# Altium schematic sheet main_master.SchDoc(main_master)
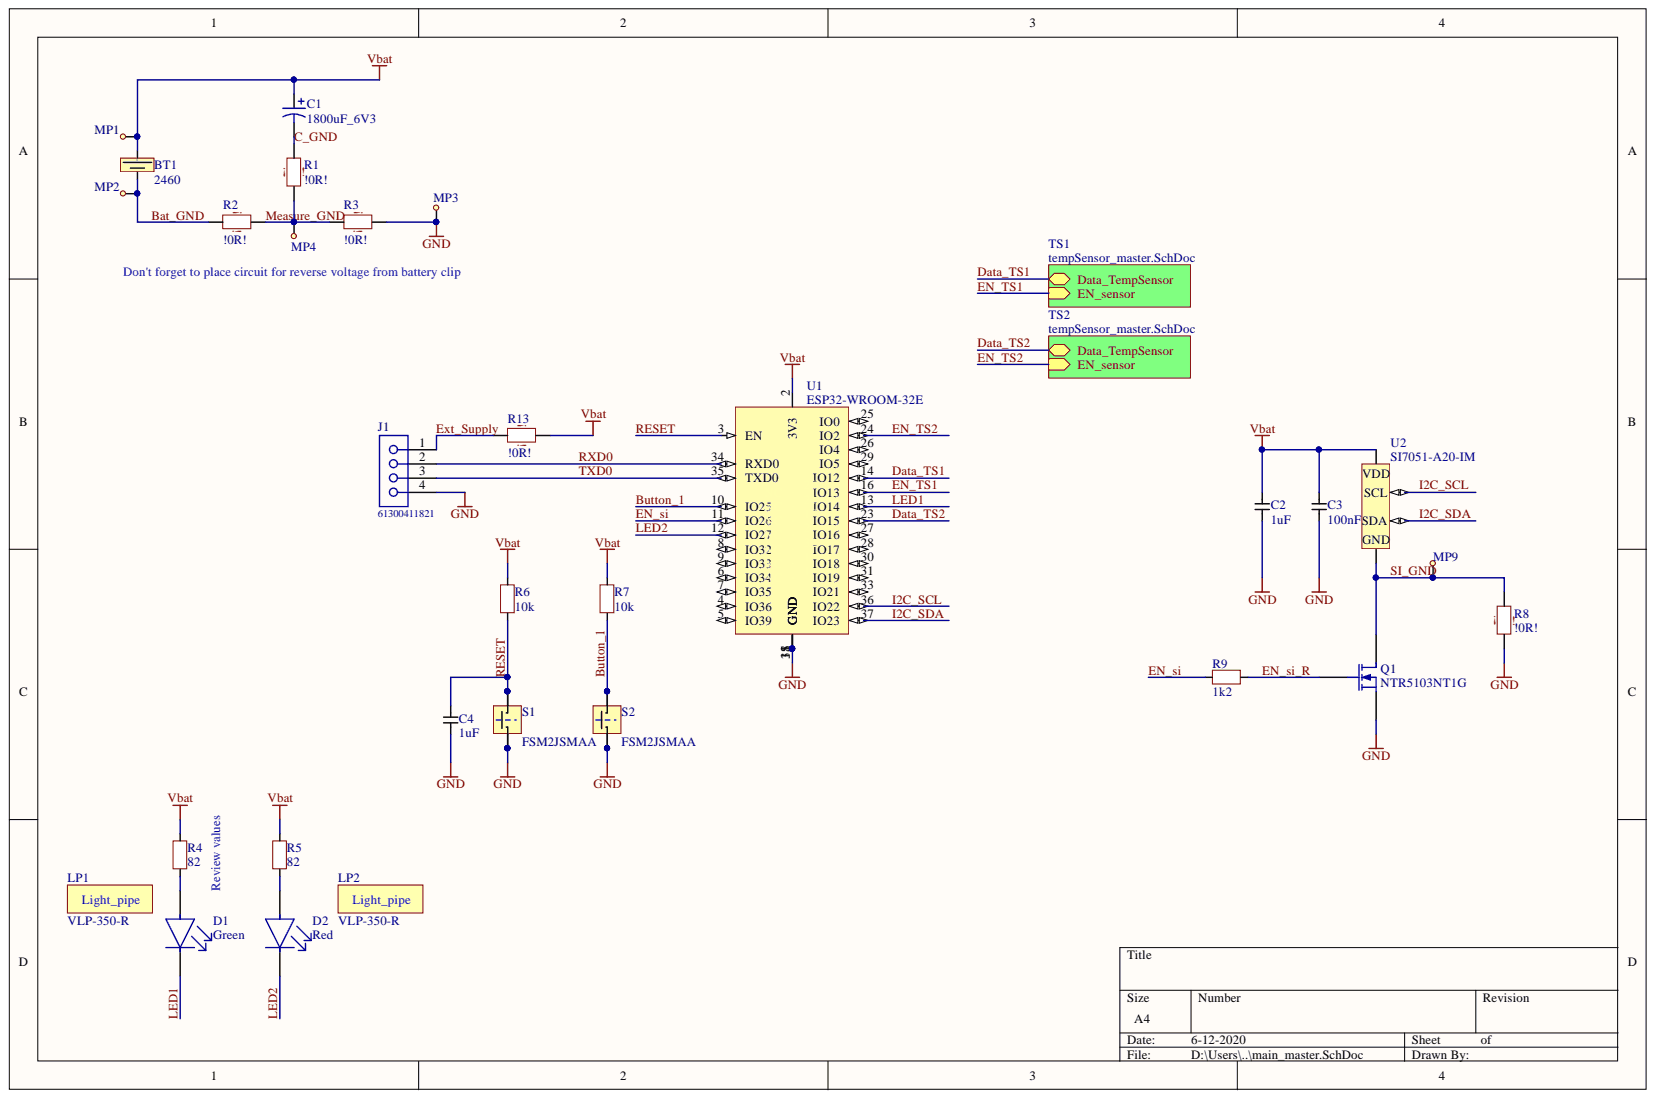
[diagram p0: main_master.SchDoc]

## Parts
BT1  2460  [KEYSTONE_2410]
C1  1800uF_6V3  [CAPAE109103X105M]
C2  1uF  [C1206]
C3  100nF  [C1206]
C4  1uF  [C1206]
D1  Green  [Kingbright_KPT-2012EC_0]
D2  Red  [Kingbright_KPT-2012EC_0]
J1  61300411821  [61300411821]
LP1  VLP-350-R  [VLP-350-R]
LP2  VLP-350-R  [VLP-350-R]
MP1  Measure_point  [MeasurePoint_1.5mm]  (value not drawn)  (no BOM)
MP2  Measure_point  [MeasurePoint_1.5mm]  (value not drawn)  (no BOM)
MP3  Measure_point  [MeasurePoint_1.5mm]  (value not drawn)  (no BOM)
MP4  Measure_point  [MeasurePoint_1.5mm]  (value not drawn)  (no BOM)
MP9  Measure_point  [MeasurePoint_1.5mm]  (value not drawn)  (no BOM)
Q1  NTR5103NT1G  [SOT23]
R1  !0R!  [R1206_Simple-Assemble]  (no BOM)
R2  !0R!  [R1206_Simple-Assemble]  (no BOM)
R3  !0R!  [R1206_Simple-Assemble]  (no BOM)
R4  82  [R1206_Simple-Assemble]
R5  82  [R1206_Simple-Assemble]
R6  10k  [R1206_Simple-Assemble]
R7  10k  [R1206_Simple-Assemble]
R8  !0R!  [R1206_Simple-Assemble]  (no BOM)
R9  1k2  [R1206_Simple-Assemble]
R13  !0R!  [R1206_Simple-Assemble]  (no BOM)
S1  FSM2JSMAA  [FP-FSM2JSMAA-MFG]
S2  FSM2JSMAA  [FP-FSM2JSMAA-MFG]
U1  ESP32-WROOM-32E  [ESP32­WROOM­32E]
U2  SI7051-A20-IM  [3x3 6-pin DFN]

## Nets
GND: C2.1 C3.1 C4.1 J1.4 MP3.1 Q1.2 R3.2 R8.1 S1.1 S1.3 S2.1 S2.3 U1.1(GND) U1.15 U1.38
Vbat: BT1.1 C1.1 C2.2 C3.2 MP1.1 R4.2 R5.2 R6.2 R7.2 R13.2 U1.2(3V3) U2.5
Bat_GND: BT1.2 MP2.1 R2.1
Button_1: R7.1 S2.2 S2.4 U1.10(IO25)
C_GND: C1.2 R1.2
Data_TS1: U1.14(IO12)
Data_TS2: U1.23(IO15)
EN_si: R9.1 U1.11(IO26)
EN_si_R: Q1.1 R9.2
EN_TS1: U1.16(IO13)
EN_TS2: U1.24(IO2)
Ext_Supply: J1.1 R13.1
I2C_SCL: U1.36(IO22) U2.6(SCL)
I2C_SDA: U1.37(IO23) U2.1(SDA)
LED1: D1.1 U1.13(IO14)
LED2: D2.1 U1.12(IO27)
Measure_GND: MP4.1 R1.1 R2.2 R3.1
RESET: C4.2 R6.1 S1.2 S1.4 U1.3(EN)
RXD0: J1.2 U1.34(RXD0)
SI_GND: MP9.1 Q1.3 R8.2 U2.2
TXD0: J1.3 U1.35(TXD0)
NetD1_2: D1.2 R4.1
NetD2_2: D2.2 R5.1
NetU1_4: U1.4(IO36)
NetU1_5: U1.5(IO39)
NetU1_6: U1.6(IO34)
NetU1_7: U1.7(IO35)
NetU1_8: U1.8(IO32)
NetU1_9: U1.9(IO33)
NetU1_17: U1.17
NetU1_18: U1.18
NetU1_19: U1.19
NetU1_20: U1.20
NetU1_21: U1.21
NetU1_22: U1.22
NetU1_25: U1.25(IO0)
NetU1_26: U1.26(IO4)
NetU1_27: U1.27(IO16)
NetU1_28: U1.28(IO17)
NetU1_29: U1.29(IO5)
NetU1_30: U1.30(IO18)
NetU1_31: U1.31(IO19)
NetU1_32: U1.32
NetU1_33: U1.33(IO21)

## Library data of the parts
BT1 = 2460: Battery Holder, AA x 1, Through Hole; Brand: KEYSTONE; Type: 2460; Mouser_Price: 1,10; Mouser_Order_Code: 534-2460; Library Name: Misc.IntLib; Farnell_Price: 1,80; Farnell_Order_Code: 1702629; Component Kind: Standard
C1 = 1800uF_6V3: SMD Aluminium Electrolytic Capacitor, Radial Can, 1800 µF, 10 V, 0.092 ohm, TRV Series; Manufacturer Part Number: EEE-FN0J182UV; Manufacturer: Panasonic; Voltage Rating: 10V; Value: 1800uF; Capacitance: 1.8mF; Supplier Unit Price 1: €0.98708; Supplier Part Number 1: 667-EEE-FN0J182UV; Supplier 1: Mouser; Mount: Surface Mount; Library Name: Capacitors_electrolytic.SchLib; Component Kind: Standard; Category: Aluminum Electrolytic Capacitors
C2 C4 = 1uF,1206: 1uF, 1206; Library Name: Capacitors.IntLib; Component Kind: Standard; Capacitance: 1uF
C3 = 100nF,1206: 100nF, 1206; Library Name: Capacitors.IntLib; Component Kind: Standard; Capacitance: 100nF
D1 = AP2012MGC: Standard LEDs - SMD GRN WATER CLEAR 0805; Manufacturer: Kingbright; Forward Voltage: 2.1V; Forward Current: 20mA; Supplier Part Number 1: 604-AP2012MGC; Supplier 1: Mouser; Manufacturer Part Number: AP2012MGC; Component Kind: Standard; Color: Green; Category: LEDs; Case/Package: 0805; Library Name: LED_AND_DISPLAYS.IntLib
D2 = KPT-2012EC: KPT-2012EC -  LED, Low Power, Red, SMD, 0805 [2012 Metric], 30 mA, 2 V, 617 nm; Order Code: 2099236; Brand: KINGBRIGHT; Type: KPT-2012EC; Supplier: Farnell; Price: 0,112; Component Kind: Standard; Library Name: LED_AND_DISPLAYS.IntLib
J1 = 61300411821: THT Vertical Socket Header WR-PHD, Pitch 2.54 mm, Single Row, 4 pins; Working Voltage: 250 VAC; Withstanding Voltage: 500 VAC; Rated Current: 3 A; Pitch: 2.54 mm; Pin Number: 4; Gender: Female; Contact Resistance: 20 mOhm; Row Number: Single; Published Date: August 2018; Part Number: 61300411821; Packaging: Tray; Orientation: Vertical; Operating Temperature: -40 to 125 degC; Mounting Technology: THT; ComponentLink2URL: https://katalog.we-online.de/em/datasheet/6130xx11821.pdf; ComponentLink2Description: Datasheet; ComponentLink1URL: http://www.we-online.de; ComponentLink1Description: Manufacturer; Component Kind: Standard; Publisher: Wurth Elektronik; Library Name: Connectoren.IntLib
LP1 LP2 = VLP-350-R: LIGHT PIPE, SINGLE, ROUND, PCB; Manufacturer: BIVAR; Manufacturer Part Number: VLP-350-R; Component Kind: Standard; Library Name: Light_Pipes.IntLib
MP1-MP4 MP9 = Measure_point: Measurepoint; Library Name: Misc.IntLib
Q1 = NTR5103NT1G: Power MOSFET, N Channel, 60 V, 260 mA, 1 ohm, SOT-23, Surface Mount; Manufacturer: ON Semiconductor; Manufacturer Part Number: NTR5103NT1G; Library Name: MOSFETS.IntLib; Component Kind: Standard
R1-R3 R8 R13 = 0R, optional resistor: normally shorted, but easy to change to an resistor footprint
  R1-R3 R8: Library Name: Resistors.SchLib
  R13: Library Name: Resistors.IntLib
R4 R5 = 82, 1206: Brand: MULTICOMP; Supplier: Farnell; Resistance: 82; Library Name: Resistors.IntLib; Component Kind: Standard
R6 R7 = 10k, 1206: 10k, 1206, 250mW, 200V; Order Code: 2861956; Brand: TE CONNECTIVITY; Type: CRGCQ1206J10K; Supplier: Farnell; Resistance: 10k; Price: 0,0139; Power: 250mW; Library Name: Resistors.IntLib; Component Kind: Standard
R9 = 1k2, 1206: SMD Chip Resistor, 1206 [3216 Metric], 1 kohm, MCSR 12 Series, 200 V, Ceramic, 250 mW; Power: 250mW; Order Code: 2446791; Brand: MULTICOMP; Type: MCWR12X1201FTL; Supplier: Farnell; Resistance: 1k2; Price: 0,0298; Library Name: Resistors.IntLib; Component Kind: Standard
S1 S2 = FSM2JSMAA: Tactile Switch, FSMJSM Series, Top Actuated, Surface Mount, Round Button, 260 gf, 50mA at 24VDC; Manufacturer: TE Connectivity; Voltage Rating (DC): 24V; Connector Type: SMD/SMT; Supplier Unit Price 1: €0.13022; Mount: Surface Mount; Manufacturer Part Number: FSM2JSMAA; Library Name: Misc.SchLib; Component Kind: Standard; Category: Pushbutton Switches; Case/Package: SMD/SMT
U1 = ESP32-WROOM-32E(M113EH2800PH3Q0): USB-modules (802.11) SMD Module ESP32-WROOM-32E, ESP32-D0WD-V3, 16 MB SPI flash, PCB antenna; Order Code: 356-ESP32WRM32E128PH; Brand: Espressif Systems; Type: ESP32-WROOM-32E; Supplier: MOUSER; Price: 2,64; Library Name: Misc.IntLib; Component Kind: Standard
U2 = SI7051-A20-IM: Temperature Sensor IC, Digital, ± 0.1°C, -40 °C, 125 °C, DFN, 6 Pins; Order Code: 2769160; Brand: SILICON LABS; Type: SI7051-A20-IM; Supplier: Farnell; Price: 1,98; Library Name: IC's.IntLib; Component Kind: Standard; ClassName: Smallpitch
